# Altium schematic sheet NFC and UHF RFID Interface.SchDoc
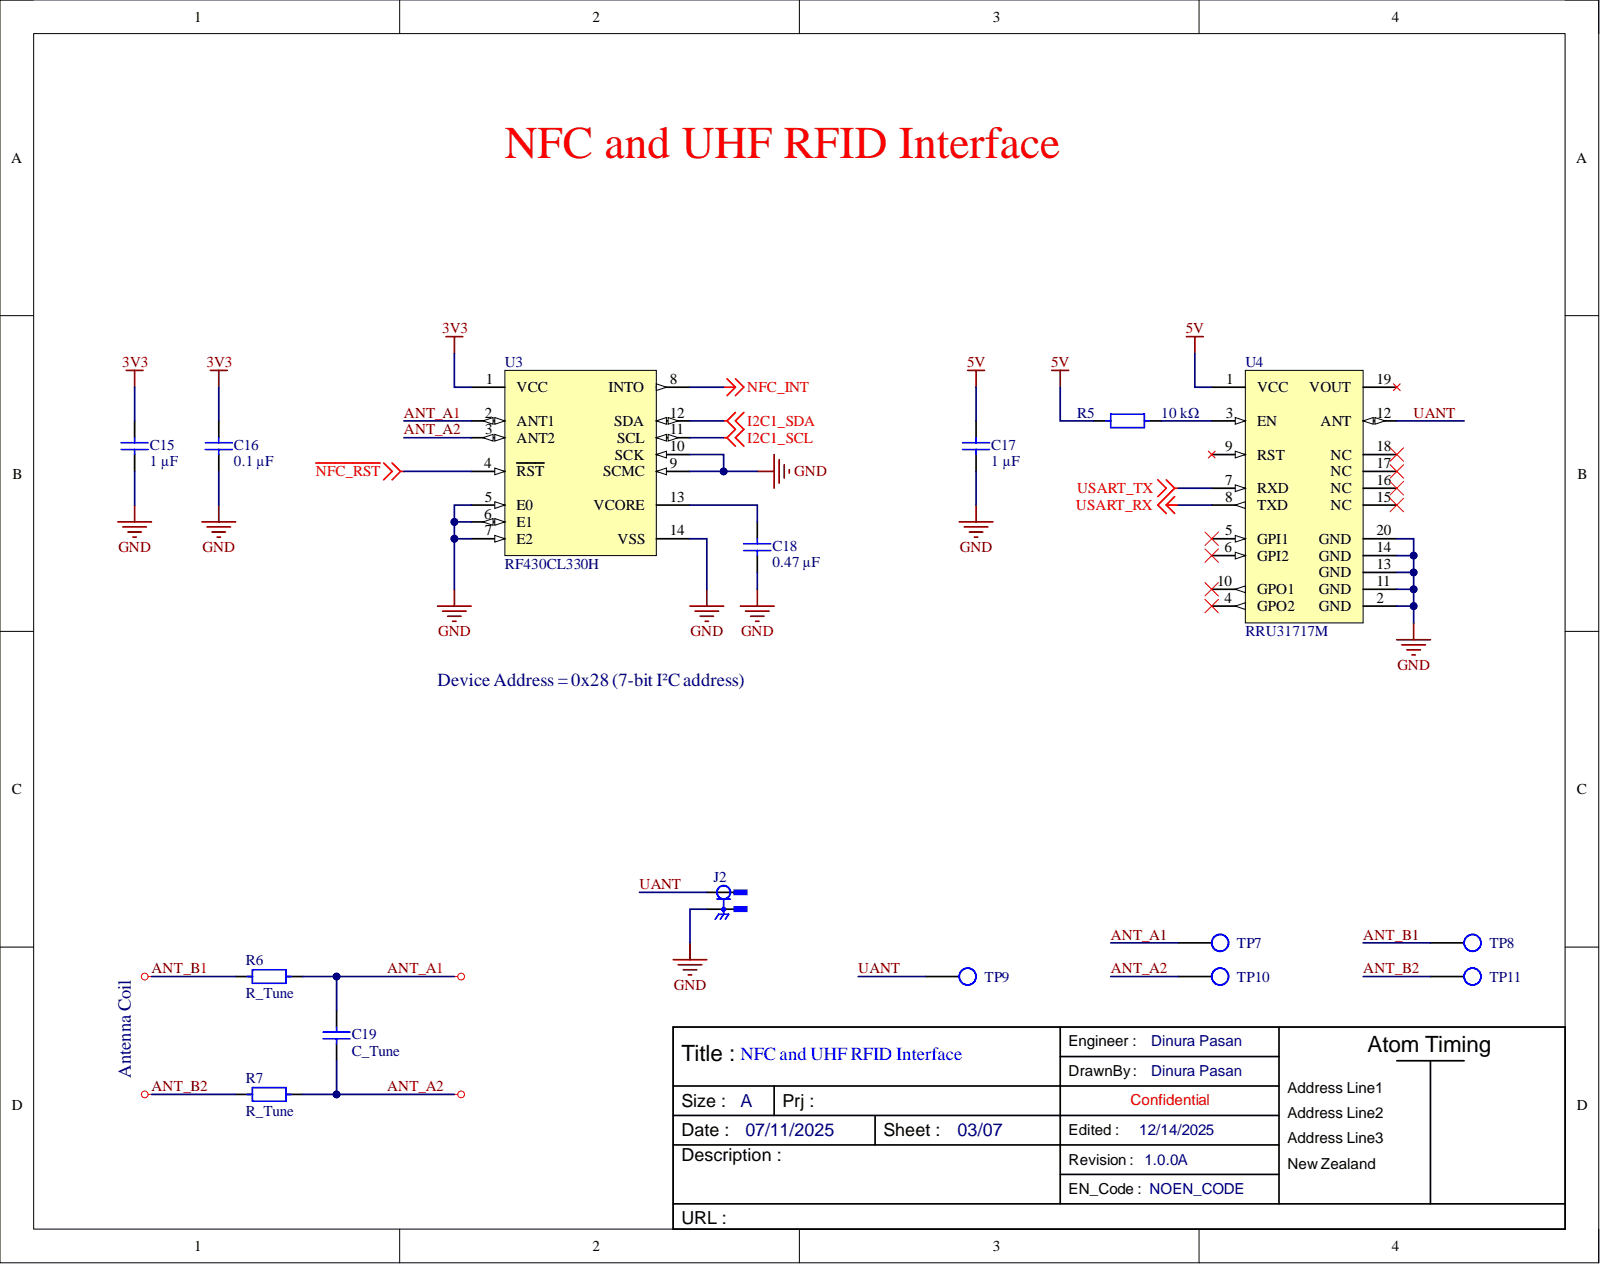
[diagram p0: NFC and UHF RFID Interface.SchDoc]

## Parts
C15  1 µF  [TDK_CGA0805N]
C16  0.1 µF  [TDK_CGA0805N]
C17  1 µF  [TDK_CGA0805N]
C18  0.47 µF  [TDK_CGA0805N]
C19  C_Tune  [TDK_CGA0805N]  (no BOM)
J2  RFPC-MMCX04-F-R  [GRADCONN_RFPC-MMCX04-F]  (value not drawn)
R5  10 kΩ  [Panasonic_ERA2AN]
R6  R_Tune  [Panasonic_ERA6AN]  (no BOM)
R7  R_Tune  [Panasonic_ERA6AN]  (no BOM)
TP7  TestPoint  [TESTPOINT]  (value not drawn)
TP8  TestPoint  [TESTPOINT]  (value not drawn)
TP9  TestPoint  [TESTPOINT]  (value not drawn)
TP10  TestPoint  [TESTPOINT]  (value not drawn)
TP11  TestPoint  [TESTPOINT]  (value not drawn)
U3  RF430CL330H  [TI-PW0014A]
U4  RRU31717M  [RRUx1717M]

## Nets
3V3: C15.2 C16.2 U3.1(VCC)
5V: C17.2 R5.1 U4.1(VCC)
GND: C15.1 C16.1 C17.1 C18.1 J2.G U3.5(E0) U3.6(E1) U3.7(E2) U3.9(SCMC) U3.10(SCK) U3.14(VSS) U4.2(GND) U4.11(GND) U4.13(GND) U4.14(GND) U4.20(GND)
I2C1_SCL: U3.11(SCL)
I2C1_SDA: U3.12(SDA)
~{NFC_RST}: U3.4(RST)
NFC_INT: U3.8(INTO)
USART_RX: U4.8(TXD)
USART_TX: U4.7(RXD)
ANT_A1: C19.2 R6.2 TP7.1 U3.2(ANT1)
ANT_A2: C19.1 R7.2 TP10.1 U3.3(ANT2)
ANT_B1: R6.1 TP8.1
ANT_B2: R7.1 TP11.1
UANT: J2.1 TP9.1 U4.12(ANT)
NetC18_2: C18.2 U3.13(VCORE)
NetR5_2: R5.2 U4.3(EN)

Not connected: U4 4(GPO2) 5(GPI1) 6(GPI2) 9(RST) 10(GPO1) 15(NC) 16(NC) 17(NC) 18(NC) 19(VOUT)

## Library data of the parts
C15 C17 = CGA4J3X7R1H105K125AB: 1 µF ±10% 50V X7R -55°C ~ 125°C 0805 (2012 Metric); Manufacturer: TDK Corporation; Database Table Name: Sheet1$; Eco Plan: RoHS & Green; Initial Voltage: 0V; TEMP: 25; Value: 1u; Capacitance: 1 µF; Category: Ceramic Capacitor (MLCC); Component Kind: Standard; Datasheet: https://product.tdk.com/system/files/dam/doc/product/capacitor/ceramic/mlcc/catalog/mlcc_automotive_general_en.pdf; Library Name: MLCC.DbLib; Manufacturer Part Number: CGA4J3X7R1H105K125AB; Operating Temperature: -55°C ~ 125°C; Package / Case: 0805 (2012 Metric); Ratings: AEC-Q200; Series: CGA; Simulation: C_Capacitor_Default; Size / Dimension: 0.079" L x 0.049" W (2.00mm x 1.25mm); Supplier 1: Digi-Key; Supplier Part Number 1: 445-6961-2-ND; Temperature Coefficient: X7R; Thickness (Max): 0.059" (1.50mm); Tolerance: ±10%; Voltage - Rated: 50V
C16 = CGA4J2X7R2A104K125AE: 0.1 µF ±10% 100V X7R -55°C ~ 125°C 0805 (2012 Metric); Manufacturer: TDK Corporation; Database Table Name: Sheet1$; Eco Plan: RoHS & Green; Initial Voltage: 0V; TEMP: 25; Value: 0.1u; Capacitance: 0.1 µF; Category: Ceramic Capacitor (MLCC); Component Kind: Standard; Datasheet: https://product.tdk.com/system/files/dam/doc/product/capacitor/ceramic/mlcc/catalog/mlcc_automotive_general_en.pdf; Library Name: MLCC.DbLib; Manufacturer Part Number: CGA4J2X7R2A104K125AE; Operating Temperature: -55°C ~ 125°C; Package / Case: 0805 (2012 Metric); Ratings: AEC-Q200; Series: CGA; Simulation: C_Capacitor_Default; Size / Dimension: 0.079" L x 0.049" W (2.00mm x 1.25mm); Supplier 1: Digi-Key; Supplier Part Number 1: 445-15961-2-ND; Temperature Coefficient: X7R; Thickness (Max): 0.059" (1.50mm); Tolerance: ±10%; Voltage - Rated: 100V
C18 = CGA4J3X7R1H474K125AE: 0.47 µF ±10% 50V X7R -55°C ~ 125°C 0805 (2012 Metric); Manufacturer: TDK Corporation; Database Table Name: Sheet1$; Eco Plan: RoHS & Green; Initial Voltage: 0V; TEMP: 25; Value: 0.47u; Capacitance: 0.47 µF; Category: Ceramic Capacitor (MLCC); Component Kind: Standard; Datasheet: https://product.tdk.com/system/files/dam/doc/product/capacitor/ceramic/mlcc/catalog/mlcc_automotive_general_en.pdf; Library Name: MLCC.DbLib; Manufacturer Part Number: CGA4J3X7R1H474K125AE; Operating Temperature: -55°C ~ 125°C; Package / Case: 0805 (2012 Metric); Ratings: AEC-Q200; Series: CGA; Simulation: C_Capacitor_Default; Size / Dimension: 0.079" L x 0.049" W (2.00mm x 1.25mm); Supplier 1: Digi-Key; Supplier Part Number 1: 445-8786-2-ND; Temperature Coefficient: X7R; Thickness (Max): 0.059" (1.50mm); Tolerance: ±10%; Voltage - Rated: 50V
C19 = CGA4J2X7R2A104K125AE: 0.1 µF ±10% 100V X7R -55°C ~ 125°C 0805 (2012 Metric); Manufacturer: TDK Corporation; Capacitance: 0.1 µF; Database Table Name: Sheet1$; Eco Plan: RoHS & Green; Initial Voltage: 0V; TEMP: 25; Value: 0.1u; Category: Ceramic Capacitor (MLCC); Datasheet: https://product.tdk.com/system/files/dam/doc/product/capacitor/ceramic/mlcc/catalog/mlcc_automotive_general_en.pdf; Library Name: MLCC.DbLib; Manufacturer Part Number: CGA4J2X7R2A104K125AE; Operating Temperature: -55°C ~ 125°C; Package / Case: 0805 (2012 Metric); Ratings: AEC-Q200; Series: CGA; Simulation: C_Capacitor_Default; Size / Dimension: 0.079" L x 0.049" W (2.00mm x 1.25mm); Supplier 1: Digi-Key; Supplier Part Number 1: 445-15961-2-ND; Temperature Coefficient: X7R; Thickness (Max): 0.059" (1.50mm); Tolerance: ±10%; Voltage - Rated: 100V
J2 = RFPC-MMCX04-F-R: MMCX Straight 6GHz Female Jack Standard No -40 to 125°C Surface Mount; Manufacturer: GradConn; Database Table Name: Sheet1$; Body Type: Jack; Category: RF Connector; Component Kind: Standard; Datasheet: https://gct.co/files/drawings/rfpc-mmcx04-f.pdf?v=2fb706ab-53d2-469f-86ad-8d71f1ea780b; Gender: Female; Interface Type: MMCX; Library Name: RFConnectors.DbLib; Manufacturer Part Number: RFPC-MMCX04-F-R; Orientation: Straight; PCB Mount Type: Surface Mount; Polarity: Standard; Series: RFPC-MMCX04-F; Supplier 1: Digi-Key; Supplier Part Number 1: 2072-RFPC-MMCX04-F-RCT-ND; Temperature Range: -40 to 125°C; Waterproof: No; Working Frequency (up to): 6GHz
R5 = ERA-2ARB103X: 10 kΩ ±0.1% 0.063W, 1/16W Thin Film 0402 (1005 Metric) 50V ±10ppm/°C -55°C ~ 155°C; Manufacturer: Panasonic Electronic Components; Database Table Name: Sheet1$; Eco Plan: RoHS & Green; Maximum Overload Voltage: 100V; Rated Voltage: √(PxR); TC: 10; TC1: 0; TEMP: 25; Value: 10kΩ; Category: SMD Thin Film Resistor; Component Kind: Standard; Composition: Thin Film; Datasheet: https://industrial.panasonic.com/cdbs/www-data/pdf/RDM0000/AOA0000C307.pdf; Height - Seated (Max): 0.016" (0.40mm); Library Name: SMD_Thin_Film_Resistors.DbLib; Manufacturer Part Number: ERA-2ARB103X; Maximum Working Voltage: 50V; Operating Temperature: -55°C ~ 155°C; Package / Case: 0402 (1005 Metric); Power (Watts): 0.063W, 1/16W; Ratings: AEC-Q200; Resistance: 10 kΩ; Series: ERA; Simulation: R_Resistor_Default; Size / Dimension: 0.039" L x 0.020" W (1.00mm x 0.50mm); Supplier 1: Digi-Key; Supplier Part Number 1: P10KCPTR-ND; TC2: 0; Temperature Coefficient: ±10ppm/°C; Tolerance: ±0.1%
R6 R7 = ERA-6ARW102V: 1 kΩ ±0.05% 0.125W, 1/8W Thin Film 0805 (2012 Metric) 100V ±10ppm/°C -55°C ~ 155°C; Manufacturer: Panasonic Electronic Components; Database Table Name: Sheet1$; Eco Plan: RoHS & Green; Maximum Overload Voltage: 200V; Rated Voltage: √(PxR); Resistance: 1 kΩ; TC: 10; TC1: 0; TEMP: 25; Value: 1kΩ; Category: SMD Thin Film Resistor; Composition: Thin Film; Datasheet: https://industrial.panasonic.com/cdbs/www-data/pdf/RDM0000/AOA0000C307.pdf; Height - Seated (Max): 0.024" (0.60mm); Library Name: SMD_Thin_Film_Resistors.DbLib; Manufacturer Part Number: ERA-6ARW102V; Maximum Working Voltage: 100V; Operating Temperature: -55°C ~ 155°C; Package / Case: 0805 (2012 Metric); Power (Watts): 0.125W, 1/8W; Ratings: AEC-Q200; Series: ERA; Simulation: R_Resistor_Default; Size / Dimension: 0.079" L x 0.049" W (2.00mm x 1.25mm); Supplier 1: Digi-Key; Supplier Part Number 1: P1.0KBSTR-ND; TC2: 0; Temperature Coefficient: ±10ppm/°C; Tolerance: ±0.05%
TP7-TP11 = TestPoint: 1mm Test Point; Component Kind: Standard; Library Name: Common_library.IntLib
U3 = RF430CL330H: Dynamic Dual Interface NFC Transponder; Manufacturer 1: Texas Instruments; Manufacturer Lifecycle Status: ACTIVE; Manufacturer Part Number 1: RF430CL330HCPWR; Case/Package 1: TSSOP; Category 1: NFC / RFID Components; Density: 0b; Description 1: NFC/RFID Tag and Transponder 13560kHz 14-Pin TSSOP T/R / IC NFC DYNAMIC TAG TARG 14-TSSOP; Frequency: 13.56MHz; Interface 1: I2C, SPI; Max Supply Voltage 1: 3.6V; Min Supply Voltage 1: 2V; Mount 1: Surface Mount; Number of Pins 1: 14; Number of UART Channels: 0; Packaging 1: Digi-Reel®; RAM Size: 3kB; Component Kind: Standard; ComponentLink1Description: https://sigma.octopart.com:443/182471031/technical_drawing/Texas-Instruments-RF430CL330HCPWR.pdf?src-supplier=Texas+Instruments; ComponentLink1URL: https://sigma.octopart.com:443/182471031/technical_drawing/Texas-Instruments-RF430CL330HCPWR.pdf?src-supplier=Texas+Instruments; ComponentLink2Description: https://octopart.com:443/opatz8j6/c1?t=GsNECc4hXYKvUlQaFhNox8tmdAs5OiTKUcl4PXcxncrFfUIemWtMDzBF1Kvkqz9OhKrlqJkrEyM6fI4C9ttJ9Fr8PsGwHqBrfOy9VcvT4ELfRAMN00odukE1Sr_JIm7UbGSst_67HeadbMpvEzp9BQwxIxqsyxZrhKNXbRdOw3w4WeTQiG4lOii3QGu1GtJFPJ_yNpw4J2XaIegT225u3lvE5qrti5_M45oceHJjETNCIG6P2OmaNzIL538-c7w3k49BHhYEOp_tzMrhLPNbQ_GO9XXvq6JTr4b3JPlwBILpFMYrtY5SXgzjOW85_92_BgJBDQan_IbjO3iTzmlYMvetThjZ5pcYRQtNMoSShGtK1kKeflTfLXaKh7mFYYC6HQ1MrJ_9Z9E&src-supplier=Texas+Instruments; ComponentLink2URL: https://octopart.com:443/opatz8j6/c1?t=GsNECc4hXYKvUlQaFhNox8tmdAs5OiTKUcl4PXcxncrFfUIemWtMDzBF1Kvkqz9OhKrlqJkrEyM6fI4C9ttJ9Fr8PsGwHqBrfOy9VcvT4ELfRAMN00odukE1Sr_JIm7UbGSst_67HeadbMpvEzp9BQwxIxqsyxZrhKNXbRdOw3w4WeTQiG4lOii3QGu1GtJFPJ_yNpw4J2XaIegT225u3lvE5qrti5_M45oceHJjETNCIG6P2OmaNzIL538-c7w3k49BHhYEOp_tzMrhLPNbQ_GO9XXvq6JTr4b3JPlwBILpFMYrtY5SXgzjOW85_92_BgJBDQan_IbjO3iTzmlYMvetThjZ5pcYRQtNMoSShGtK1kKeflTfLXaKh7mFYYC6HQ1MrJ_9Z9E&src-supplier=Texas+Instruments; ComponentLink3Description: https://datasheet.octopart.com:443/RF430CL330HCPWR-Texas-Instruments-datasheet-37725864.pdf?src-supplier=Texas+Instruments; ComponentLink3URL: https://datasheet.octopart.com:443/RF430CL330HCPWR-Texas-Instruments-datasheet-37725864.pdf?src-supplier=Texas+Instruments; ComponentLink4Description: https://datasheet.octopart.com:443/RF430CL330HCPWR-Texas-Instruments-datasheet-33025941.pdf?src-supplier=Texas+Instruments; ComponentLink4URL: https://datasheet.octopart.com:443/RF430CL330HCPWR-Texas-Instruments-datasheet-33025941.pdf?src-supplier=Texas+Instruments; ComponentLink5Description: https://sigma.octopart.com:443/49249819/technical_drawing/Texas-Instruments-RF430CL330HCPWR.pdf?src-supplier=Texas+Instruments; ComponentLink5URL: https://sigma.octopart.com:443/49249819/technical_drawing/Texas-Instruments-RF430CL330HCPWR.pdf?src-supplier=Texas+Instruments; ComponentLink6Description: https://datasheet.octopart.com:443/RF430CL330HCPWR-Texas-Instruments-datasheet-26769744.pdf?src-supplier=Newark; ComponentLink6URL: https://datasheet.octopart.com:443/RF430CL330HCPWR-Texas-Instruments-datasheet-26769744.pdf?src-supplier=Newark; ComponentLink7Description: https://datasheet.octopart.com:443/RF430CL330HCPWR-Texas-Instruments-datasheet-15994554.pdf?src-supplier=Newark; ComponentLink7URL: https://datasheet.octopart.com:443/RF430CL330HCPWR-Texas-Instruments-datasheet-15994554.pdf?src-supplier=Newark; ComponentLink8Description: https://datasheet.octopart.com:443/RF430CL330HCPWR-Texas-Instruments-datasheet-65713384.pdf?src-supplier=Newark; ComponentLink8URL: https://datasheet.octopart.com:443/RF430CL330HCPWR-Texas-Instruments-datasheet-65713384.pdf?src-supplier=Newark; ComponentLink9Description: https://datasheet.octopart.com:443/RF430CL330HCPWR-Texas-Instruments-datasheet-164416126.pdf?src-supplier=Augswan; ComponentLink9URL: https://datasheet.octopart.com:443/RF430CL330HCPWR-Texas-Instruments-datasheet-164416126.pdf?src-supplier=Augswan; ComponentLink10Description: https://datasheet.octopart.com:443/RF430CL330HCPWR-Texas-Instruments-datasheet-62088812.pdf?src-supplier=element14+APAC; ComponentLink10URL: https://datasheet.octopart.com:443/RF430CL330HCPWR-Texas-Instruments-datasheet-62088812.pdf?src-supplier=element14+APAC; ComponentLink11Description: https://datasheet.octopart.com:443/RF430CL330HCPWR-Texas-Instruments-datasheet-17269669.pdf?src-supplier=element14+APAC; ComponentLink11URL: https://datasheet.octopart.com:443/RF430CL330HCPWR-Texas-Instruments-datasheet-17269669.pdf?src-supplier=element14+APAC; ComponentLink12Description: https://datasheet.octopart.com:443/RF430CL330HCPWR-Texas-Instruments-datasheet-140571616.pdf?src-supplier=Arrow.cn; ComponentLink12URL: https://datasheet.octopart.com:443/RF430CL330HCPWR-Texas-Instruments-datasheet-140571616.pdf?src-supplier=Arrow.cn; ComponentLink13Description: http://sigma.octopart.com:80/reach_statement/Texas-Instruments-company-64.pdf?src-supplier=Compliance+Statements; ComponentLink13URL: http://sigma.octopart.com:80/reach_statement/Texas-Instruments-company-64.pdf?src-supplier=Compliance+Statements; ComponentLink14Description: http://sigma.octopart.com:80/conflict_mineral_statement/Texas-Instruments-company-63.pdf?src-supplier=Compliance+Statements; ComponentLink14URL: http://sigma.octopart.com:80/conflict_mineral_statement/Texas-Instruments-company-63.pdf?src-supplier=Compliance+Statements; Contact Plating: Gold; Height: 0m; Lead Free 1: Lead Free; Length: 5mm; Library Name: ICs.IntLib; Lifecycle Status: Production; Manufacturer Part Number 2: RF430CL330HIRGTR; Max Frequency: 13.56MHz; Max Operating Temperature 1: 85°C; Min Operating Temperature 1: -40°C; Radiation Hardening: No; REACH SVHC: No; RoHS 1: Compliant; Schedule B: 8542310000, 8542310000|8542310000, 8542310000|8542310000|8542310000, 8542310000|8542310000|8542310000|8542310000; Supplier 1: DigiKey; Supplier 2: DigiKey; Supplier 3: DigiKey; Supplier 4: Mouser; Supplier 5: Arrow Electronics; Supplier 6: LCSC; Supplier 7: DigiKey; Supplier 8: DigiKey; Supplier 9: DigiKey; Supplier 10: Mouser; Supplier 11: LCSC; Supplier Part Number 1: 296-36223-1-ND; Supplier Part Number 2: 296-36223-6-ND; Supplier Part Number 3: 296-36223-2-ND; Supplier Part Number 4: 595-RF430CL330HCPWR; Supplier Part Number 5: RF430CL330HCPWR; Supplier Part Number 6: C130195; Supplier Part Number 7: 296-48649-1-ND; Supplier Part Number 8: 296-48649-6-ND; Supplier Part Number 9: 296-48649-2-ND; Supplier Part Number 10: 595-RF430CL330HIRGTR; Supplier Part Number 11: C2655169; Thickness: 1mm; Width: 4.4mm
U4 = RRU31717M: E310 UHF RFID Module(1-Port); Manufacturer 1: RoyalRay; Category 1: UHF RFID Module; Component Kind: Standard; Library Name: Components.IntLib; Manufacturer Part Number 1: RRU31717M
